# Altium schematic sheet SingleSwitch.SchDoc
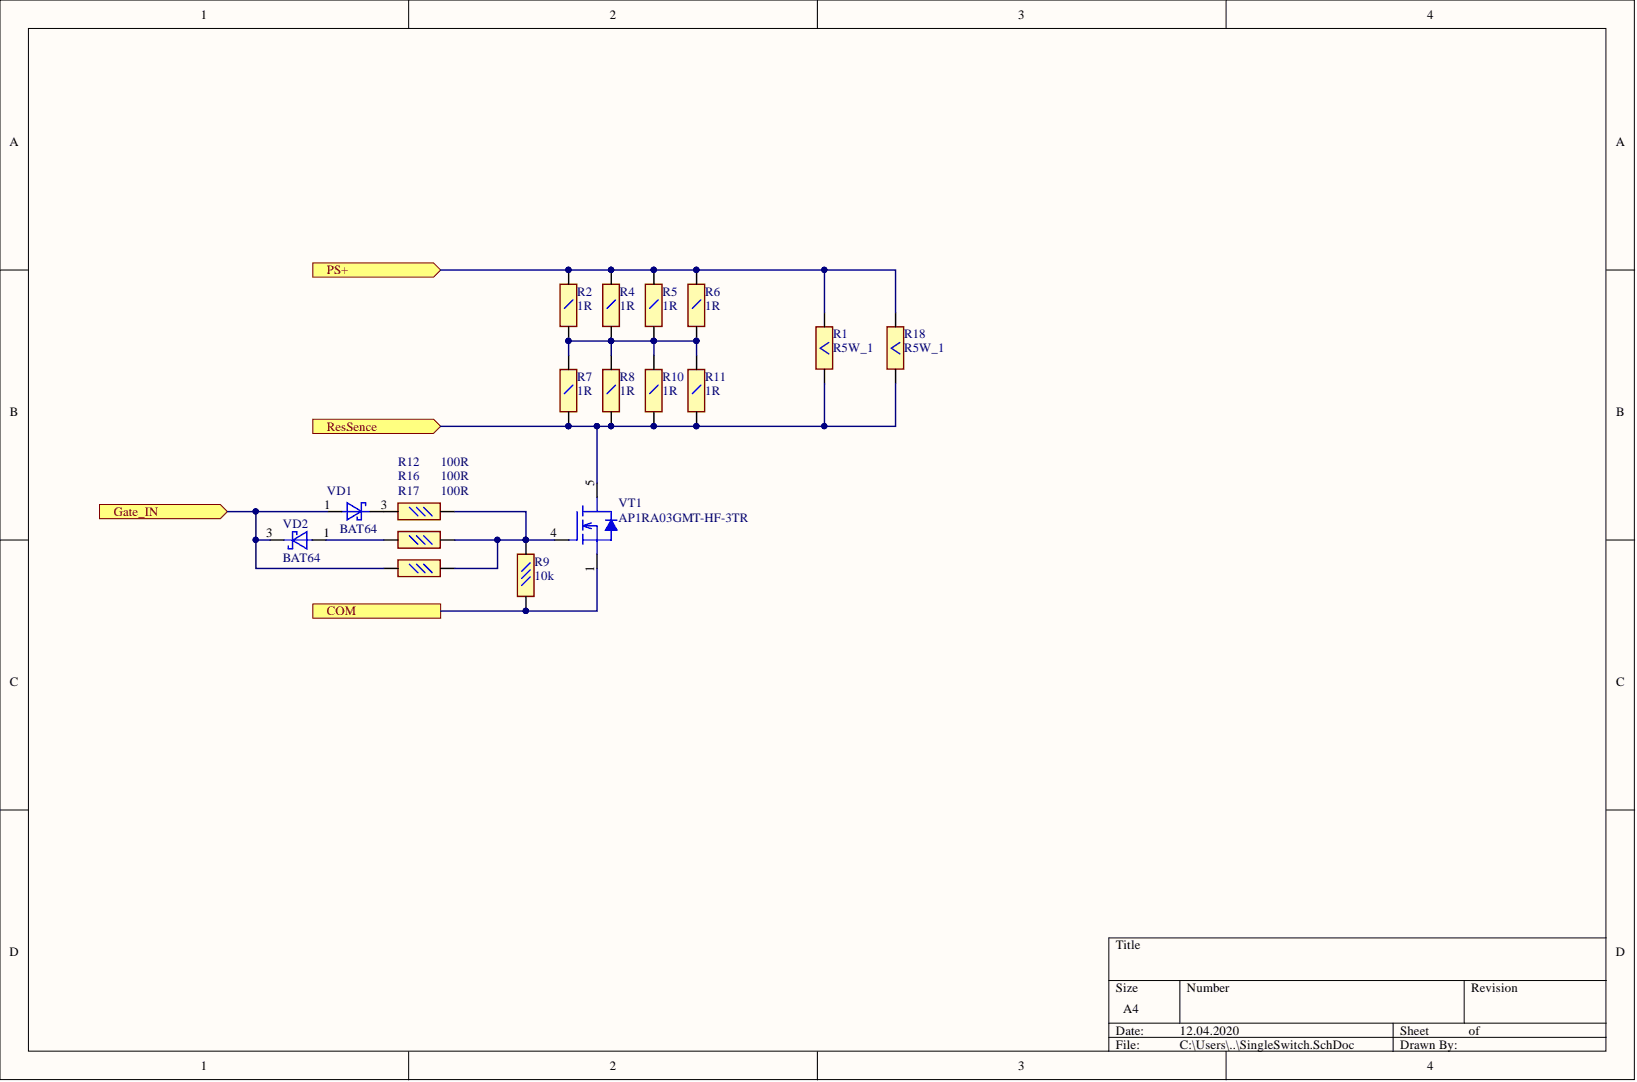
[diagram p0: SingleSwitch.SchDoc]

## Parts
R1  R5W_1  [res_206-8]
R2  1R  [1206r]
R4  1R  [1206r]
R5  1R  [1206r]
R6  1R  [1206r]
R7  1R  [1206r]
R8  1R  [1206r]
R9  10k  [0603r]
R10  1R  [1206r]
R11  1R  [1206r]
R12  100R  [0603r]
R16  100R  [0603r]
R17  100R  [0603r]
R18  R5W_1  [res_206-8]
VD1  BAT64  [SOT-23-3]
VD2  BAT64  [SOT-23-3]
VT1  AP1RA03GMT-HF-3TR  [PMPAK5x6]

## Ports
COM, Gate_IN, PS+, ResSence

## Nets
COM: R9.2 VT1.1 ; port COM
Gate_IN: R17.2 VD1.1 VD2.3 ; port Gate_IN
PS+: R1.1 R2.1 R4.1 R5.1 R6.1 R18.1 ; port PS+
ResSence: R1.2 R7.2 R8.2 R10.2 R11.2 R18.2 VT1.5 ; port ResSence
NetR2_2: R2.2 R4.2 R5.2 R6.2 R7.1 R8.1 R10.1 R11.1
NetR9_1: R9.1 R12.1 R16.1 R17.1 VT1.4
NetR12_2: R12.2 VD1.3
NetR16_2: R16.2 VD2.1

## Library data of the parts
R2 R4-R8 R10 R11 = 1R 1206 1%: Company: Uni-Ohm; Order Code: 1206S4F0100T50; Component Kind: Standard; Description: 1R 1206 1%; Type: Resistor; Value: 1R; Library Name: RESISTORs_Home.SchLib
R9 = 10k 0603 5%: Company: Yageo; ID: B112CV1113Y; Order Code: RC0603JR-0710KL; TSKD: FS-CM_StandDet_Resistor_SMD(10K_5%_100_0603); Component Kind: Standard; Description: 10k 0603 5%; Library Name: RESISTORs_Home.SchLib; Supplier 1: Digi-Key; Supplier Part Number 1: 311-10KGRCT-ND; Type: Resistor; Value: 10k
R12 R16 R17 = 100R 0603 1%: Company: Yageo; ID: B112CV1117V; Order Code: RC0603FR-07100RL; TSKD: FS-CM_StandDet_Resistor_SMD(100R_1%_100_0603); Component Kind: Standard; Description: 100R 0603 1%; Library Name: RESISTORs_Home.SchLib; Supplier 1: Digi-Key; Supplier Part Number 1: 311-100HRCT-ND; Type: Resistor; Value: 100R
VD1 VD2 = BAT64: 40V 250mA 0.57V 5ns 6pF; Company: INFINEON; ID: B115BJ1111F; Order Code: BAT64E6327; TSKD: FS-CM_StandDet_Diode_SMD(BAT64_SOT-23); Type: Diode; Component Kind: Standard; Library Name: DIODE_home.SchLib; Supplier 1: Digi-Key; Supplier Part Number 1: BAT 64 E6327CT-ND
VT1 = AP1RA03GMT-HF-3TR: N Channel, 185 A, 30 V, 0.00159 Ohm, 10 V, 1 V Rohs Compliant: Yes; Company: Advanced Power Electronics; Type: Transistor; Component Kind: Standard; ComponentLink1Description: PDF; ComponentLink1URL: https://store.comet.bg/download-file.php?id=7636; Mounting: SMD; Order Code: AP1RA03GMT-HF-3TR; Library Name: TRANZ_Library.SchLib
R1 R18 = R5W_1: Component Kind: Standard; Library Name: RESISTORs_Home.SchLib
